# Altium schematic sheet Analog Sensors.SchDoc("Analog Sensors")
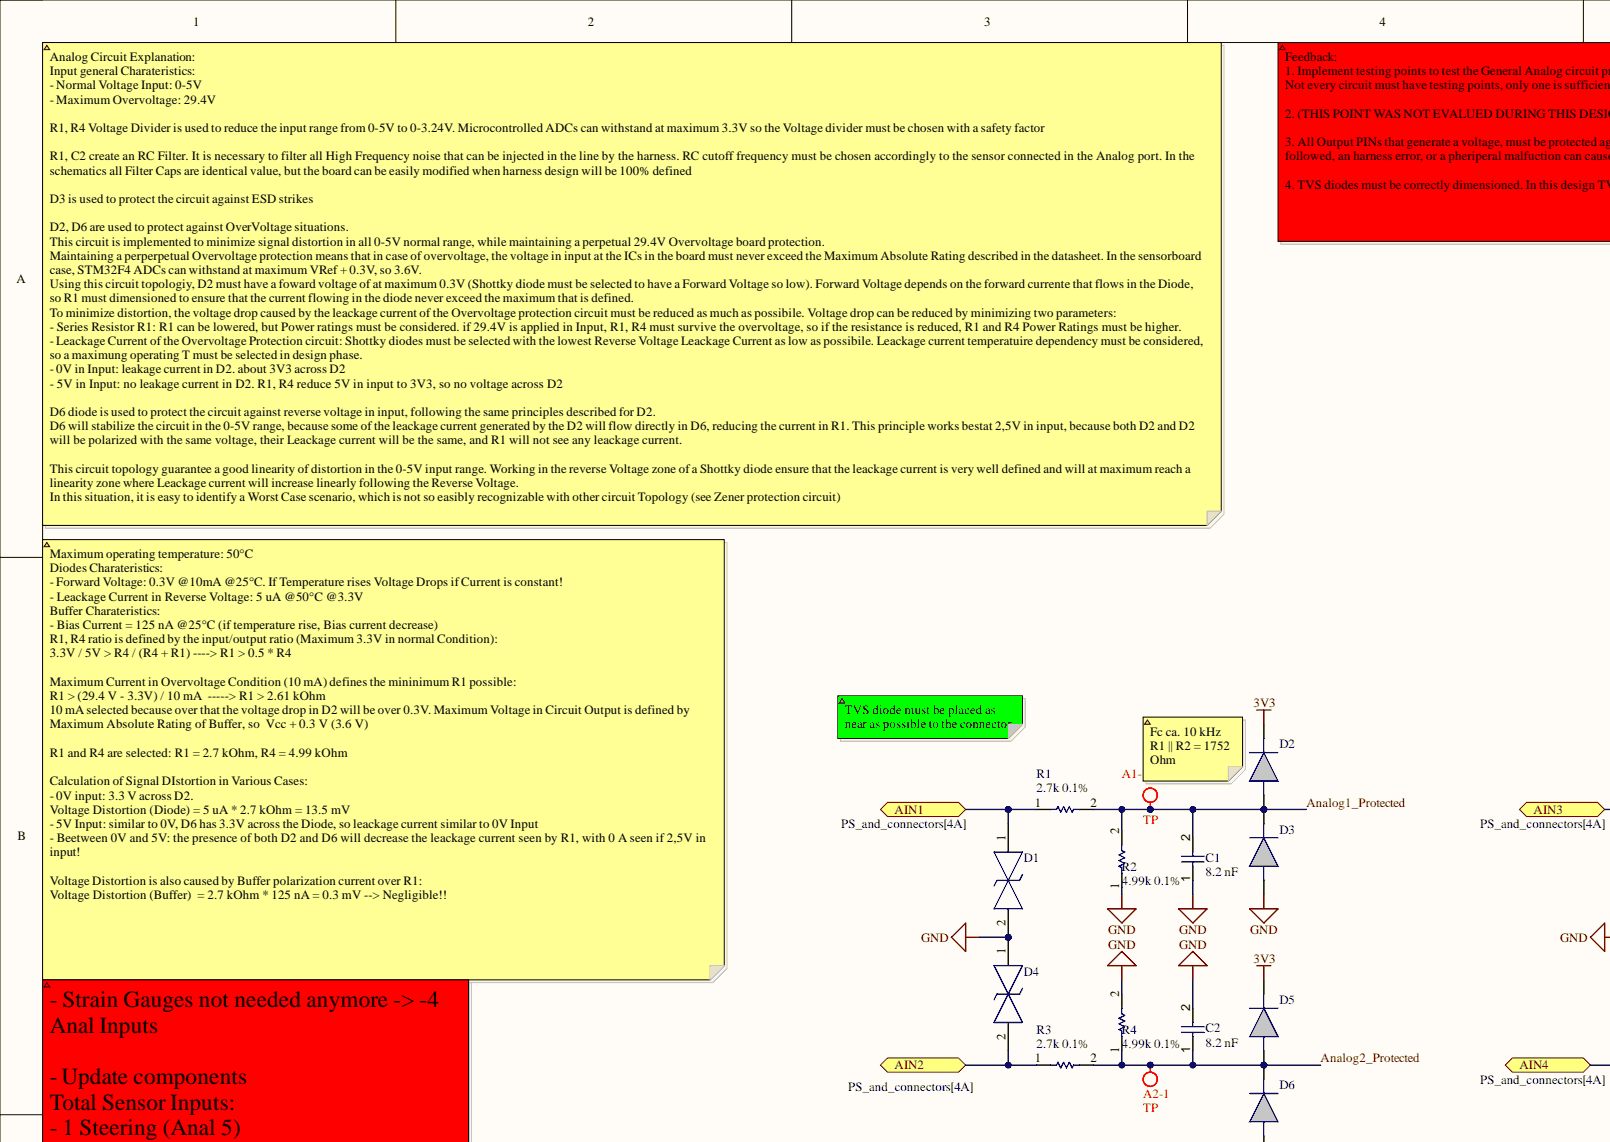
[diagram p0: Analog Sensors.SchDoc, top left]
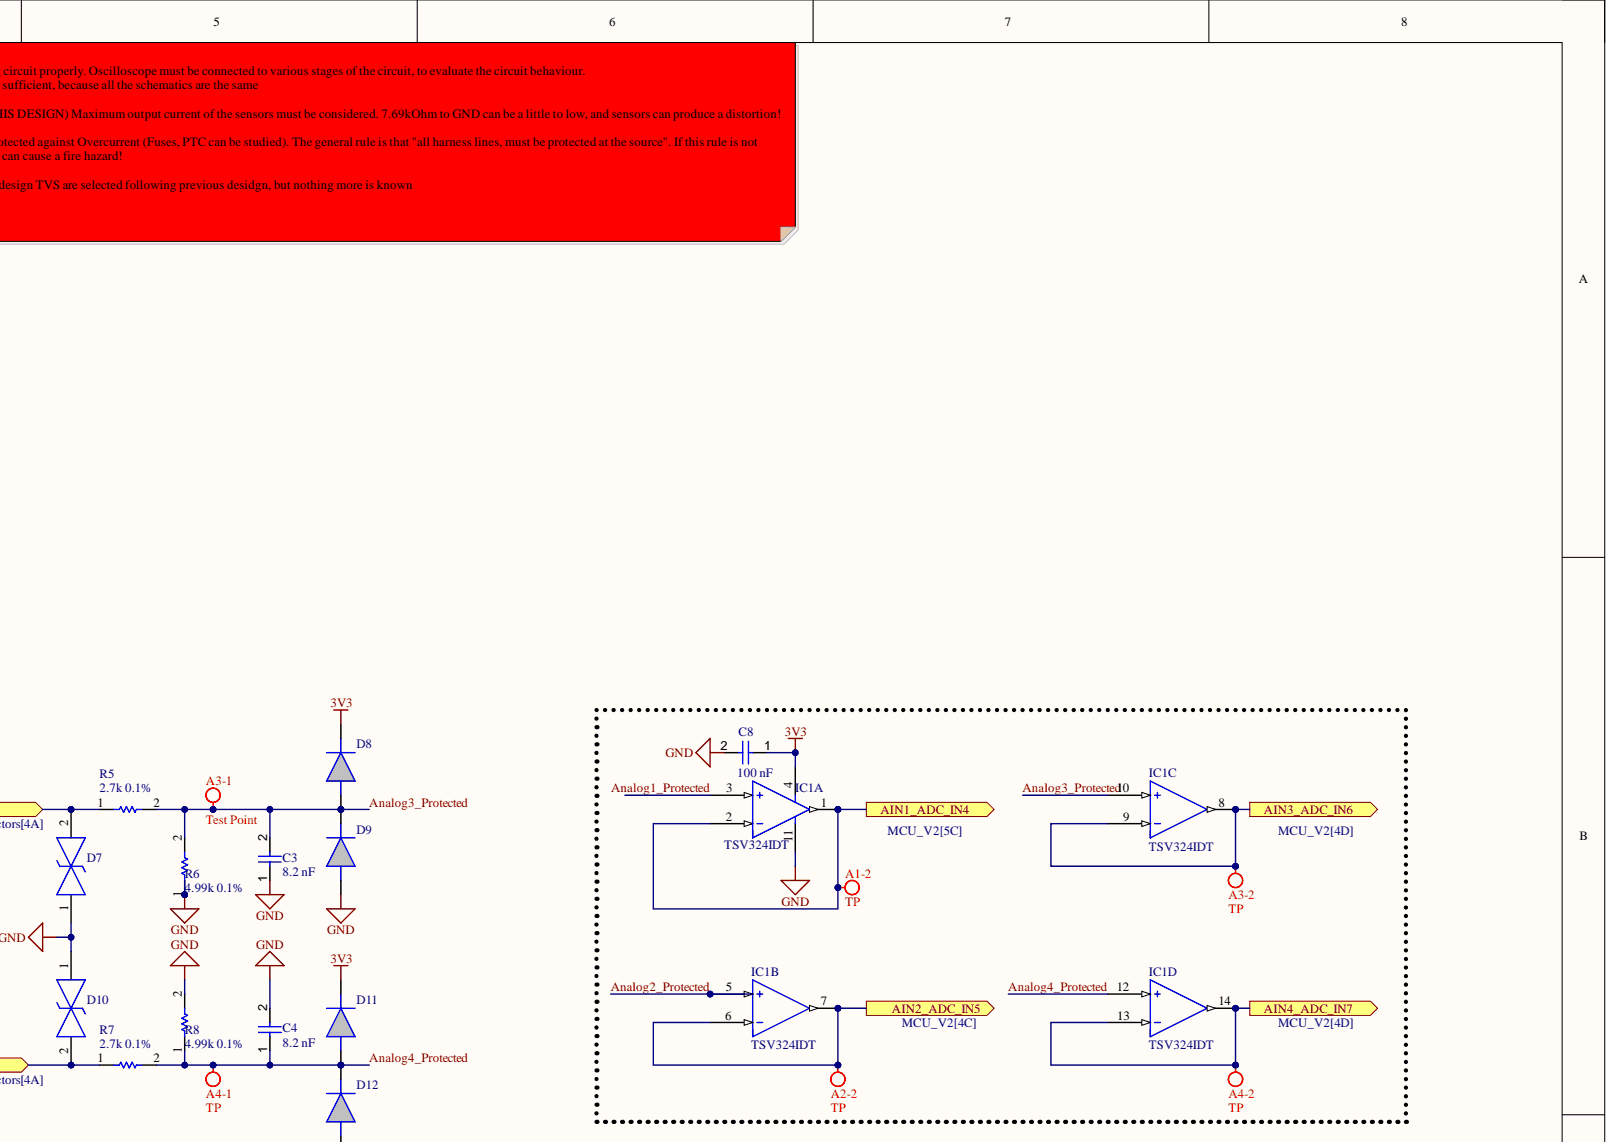
[diagram p1: Analog Sensors.SchDoc, top right]
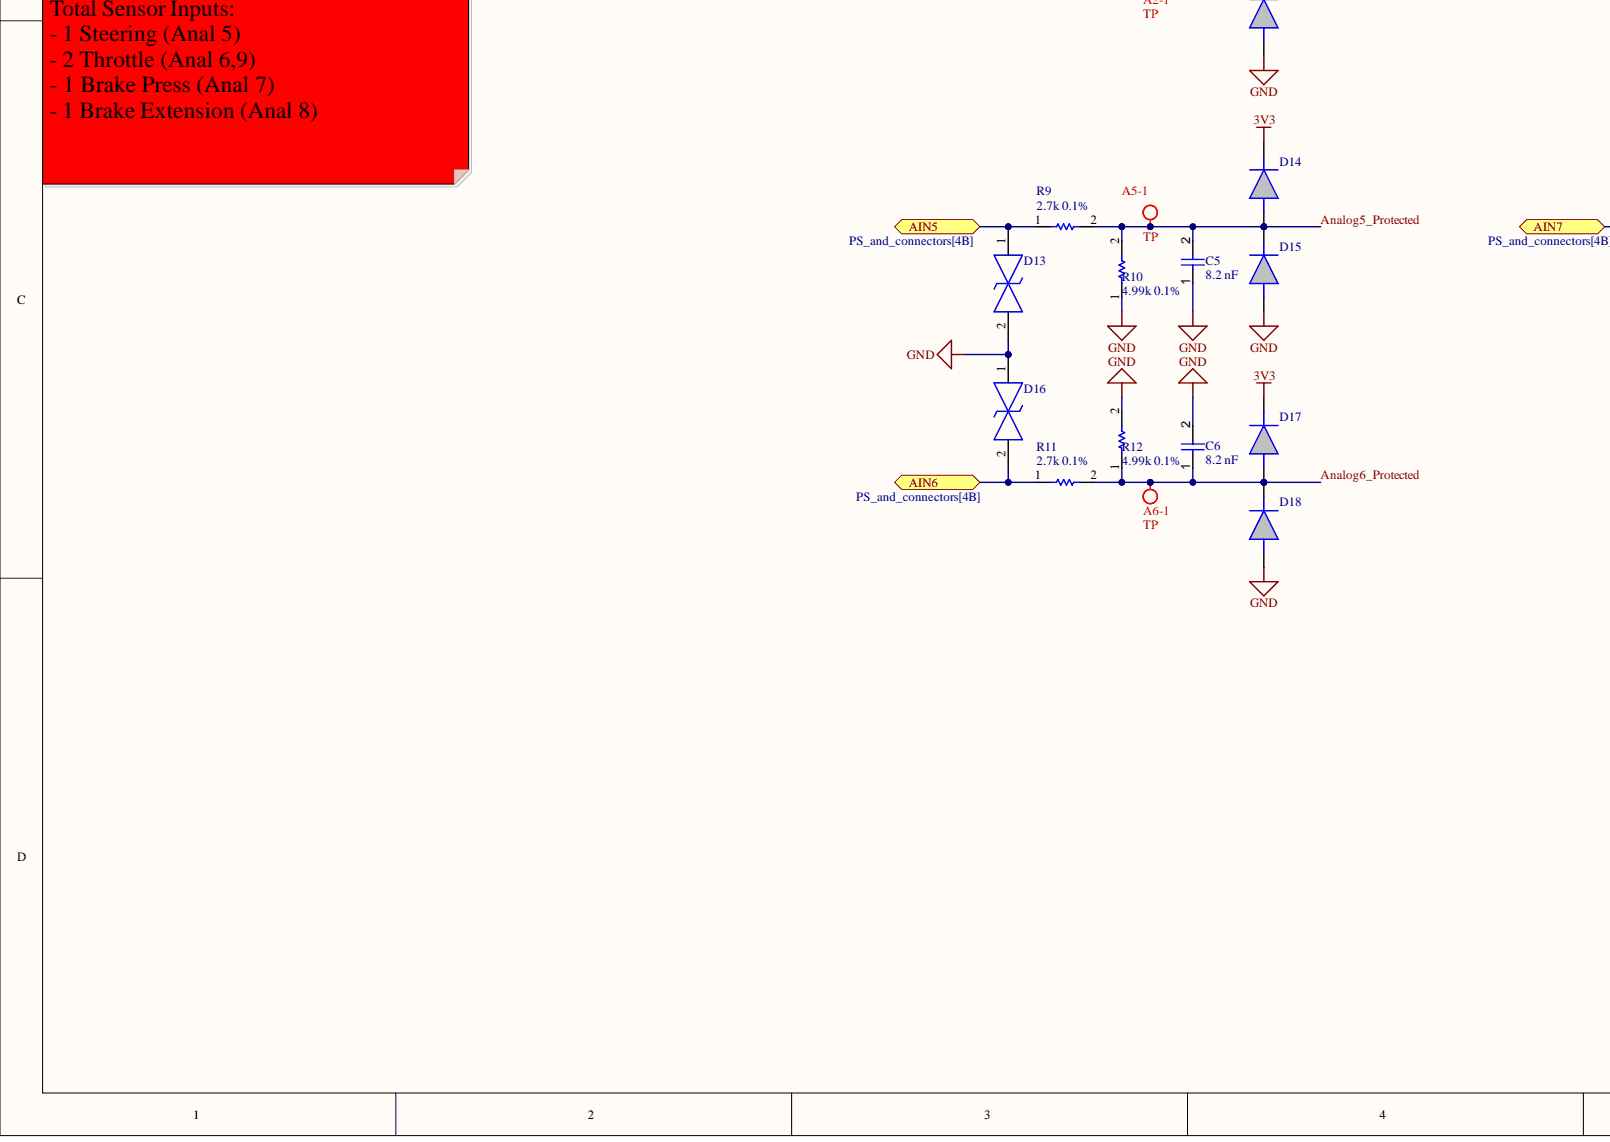
[diagram p2: Analog Sensors.SchDoc, bottom left]
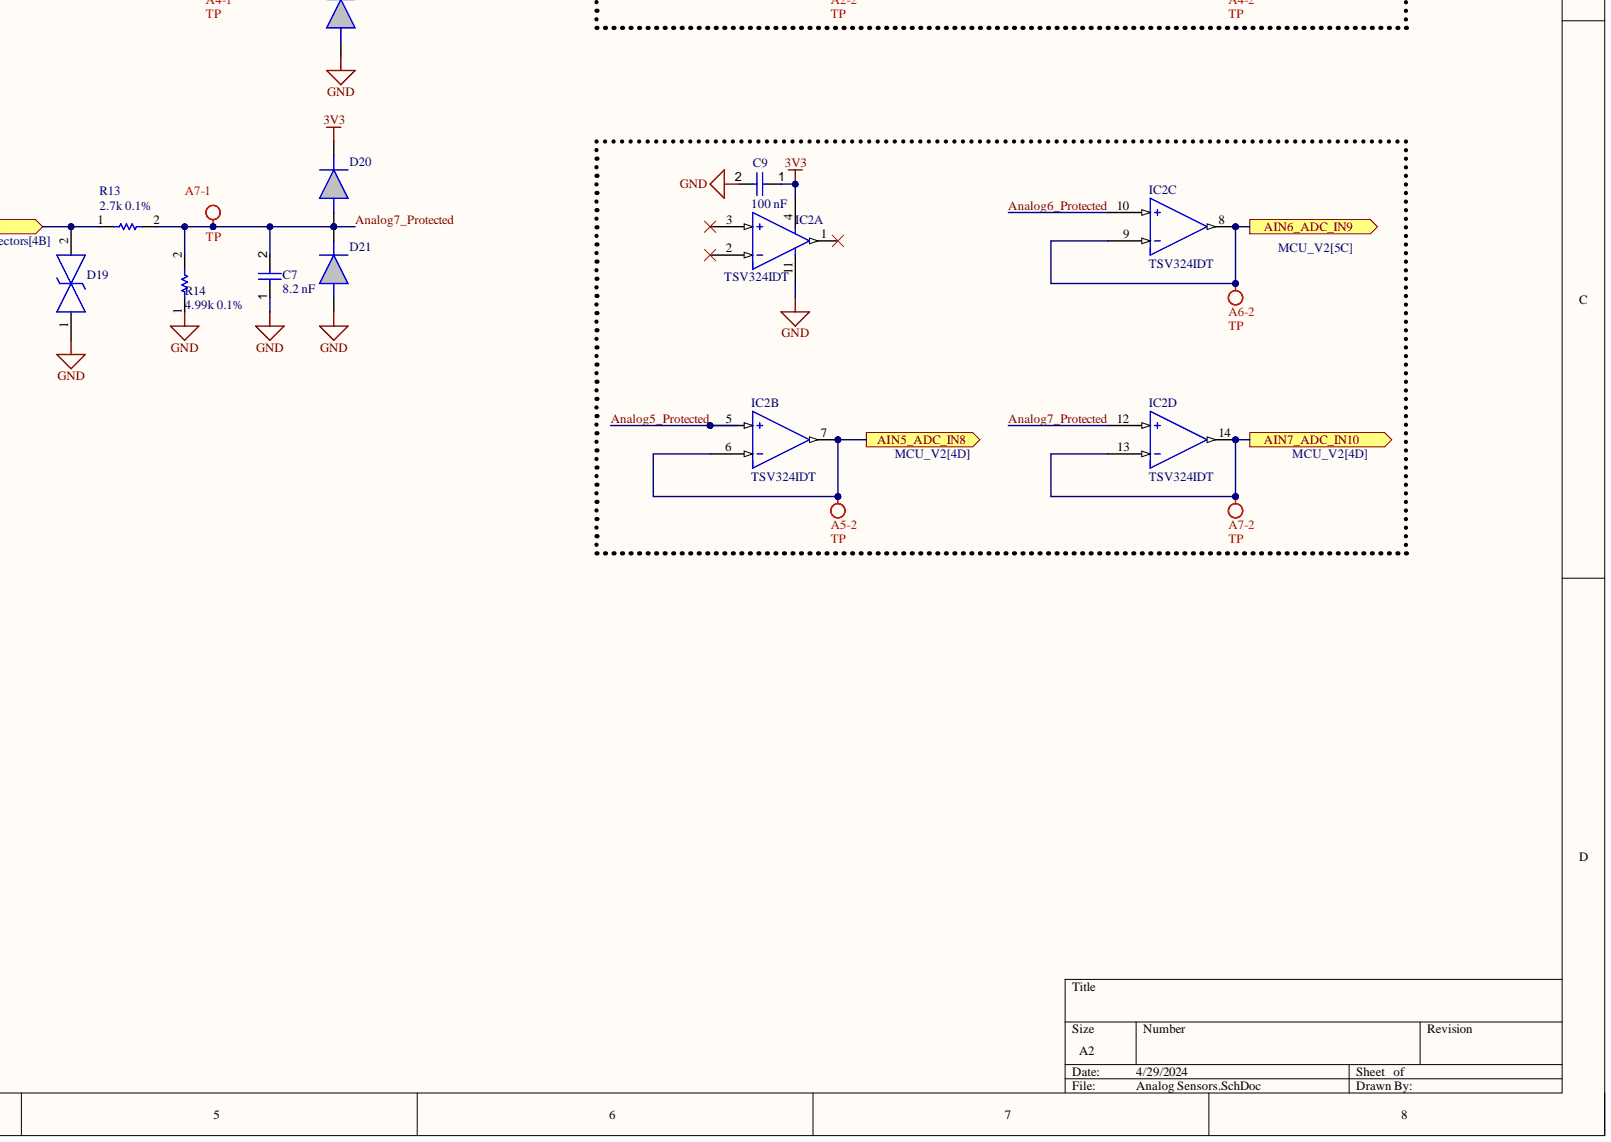
[diagram p3: Analog Sensors.SchDoc, bottom right]

## Parts
A1-1  TP
A1-2  TP
A2-1  TP
A2-2  TP
A3-1  Test Point
A3-2  TP
A4-1  TP
A4-2  TP
A5-1  TP
A5-2  TP
A6-1  TP
A6-2  TP
A7-1  TP
A7-2  TP
C1  8.2 nF
C2  8.2 nF
C3  8.2 nF
C4  8.2 nF
C5  8.2 nF
C6  8.2 nF
C7  8.2 nF
C8  100 nF
C9  100 nF
D1  SMAJ30CA-TR  (value not drawn)
D2  CD0603-B0340R  (value not drawn)
D3  CD0603-B0340R  (value not drawn)
D4  SMAJ30CA-TR  (value not drawn)
D5  CD0603-B0340R  (value not drawn)
D6  CD0603-B0340R  (value not drawn)
D7  SMAJ30CA-TR  (value not drawn)
D8  CD0603-B0340R  (value not drawn)
D9  CD0603-B0340R  (value not drawn)
D10  SMAJ30CA-TR  (value not drawn)
D11  CD0603-B0340R  (value not drawn)
D12  CD0603-B0340R  (value not drawn)
D13  SMAJ30CA-TR  (value not drawn)
D14  CD0603-B0340R  (value not drawn)
D15  CD0603-B0340R  (value not drawn)
D16  SMAJ30CA-TR  (value not drawn)
D17  CD0603-B0340R  (value not drawn)
D18  CD0603-B0340R  (value not drawn)
D19  SMAJ30CA-TR  (value not drawn)
D20  CD0603-B0340R  (value not drawn)
D21  CD0603-B0340R  (value not drawn)
IC1  TSV324IDT  (parts A,B,C,D)
IC2  TSV324IDT  (parts A,B,C,D)
R1  2.7k 0.1%
R2  4.99k 0.1%
R3  2.7k 0.1%
R4  4.99k 0.1%
R5  2.7k 0.1%
R6  4.99k 0.1%
R7  2.7k 0.1%
R8  4.99k 0.1%
R9  2.7k 0.1%
R10  4.99k 0.1%
R11  2.7k 0.1%
R12  4.99k 0.1%
R13  2.7k 0.1%
R14  4.99k 0.1%

## Ports
AIN1, AIN1_ADC_IN4, AIN2, AIN2_ADC_IN5, AIN3, AIN3_ADC_IN6, AIN4, AIN4_ADC_IN7, AIN5, AIN5_ADC_IN8, AIN6, AIN6_ADC_IN9, AIN7, AIN7_ADC_IN10

## Nets
3V3: C8.1 C9.1 D2.1 D5.1 D8.1 D11.1 D14.1 D17.1 D20.1 IC1.4 IC2.4
GND: C1.1 C2.2 C3.1 C4.2 C5.1 C6.2 C7.1 C8.2 C9.2 D1.2 D3.2 D4.1 D6.2 D7.1 D9.2 D10.1 D12.2 D13.2 D15.2 D16.1 D18.2 D19.1 D21.2 IC1.11 IC2.11 R2.1 R4.2 R6.1 R8.2 R10.1 R12.2 R14.1
AIN1: D1.1 R1.1 ; port AIN1
AIN1_ADC_IN4: A1-2.1 IC1.1 IC1.2 ; port AIN1_ADC_IN4
AIN2: D4.2 R3.1 ; port AIN2
AIN2_ADC_IN5: A2-2.1 IC1.6 IC1.7 ; port AIN2_ADC_IN5
AIN3: D7.2 R5.1 ; port AIN3
AIN3_ADC_IN6: A3-2.1 IC1.8 IC1.9 ; port AIN3_ADC_IN6
AIN4: D10.2 R7.1 ; port AIN4
AIN4_ADC_IN7: A4-2.1 IC1.13 IC1.14 ; port AIN4_ADC_IN7
AIN5: D13.1 R9.1 ; port AIN5
AIN5_ADC_IN8: A5-2.1 IC2.6 IC2.7 ; port AIN5_ADC_IN8
AIN6: D16.2 R11.1 ; port AIN6
AIN6_ADC_IN9: A6-2.1 IC2.8 IC2.9 ; port AIN6_ADC_IN9
AIN7: D19.2 R13.1 ; port AIN7
AIN7_ADC_IN10: A7-2.1 IC2.13 IC2.14 ; port AIN7_ADC_IN10
Analog1_Protected: A1-1.1 C1.2 D2.2 D3.1 IC1.3 R1.2 R2.2
Analog2_Protected: A2-1.1 C2.1 D5.2 D6.1 IC1.5 R3.2 R4.1
Analog3_Protected: A3-1.1 C3.2 D8.2 D9.1 IC1.10 R5.2 R6.2
Analog4_Protected: A4-1.1 C4.1 D11.2 D12.1 IC1.12 R7.2 R8.1
Analog5_Protected: A5-1.1 C5.2 D14.2 D15.1 IC2.5 R9.2 R10.2
Analog6_Protected: A6-1.1 C6.1 D17.2 D18.1 IC2.10 R11.2 R12.1
Analog7_Protected: A7-1.1 C7.2 D20.2 D21.1 IC2.12 R13.2 R14.2

Not connected: IC2 1 2 3

## Library data of the parts
A1-1 A1-2 A2-1 A2-2 A3-2 A4-1 A4-2 A5-1 A5-2 A6-1 A6-2 A7-2 = CMP-002-00071-2: Test Point; Component Kind: Standard; Library Name: EMEA- Squadra Corse PoliTO-  Politecnico di Torino
A3-1 = CMP-002-00071-2: Component Kind: Standard; Description: Test Point; Library Name: EMEA- Squadra Corse PoliTO-  Politecnico di Torino
A7-1 = CMP-002-00071-2: A7-1; Component Kind: Standard; Library Name: EMEA- Squadra Corse PoliTO-  Politecnico di Torino
C1 = CMP-00009-1: SMAJ30CA-TR; Component Kind: Standard; Library Name: EMEA- Squadra Corse PoliTO-  Politecnico di Torino
C2-C7 = CMP-00009-1: VJ0805Y822KXBPW1BC; Component Kind: Standard; Library Name: EMEA- Squadra Corse PoliTO-  Politecnico di Torino
C8 C9 = CMP-00009-1: VJ0805Y104MXATW1BC; Component Kind: Standard; Library Name: EMEA- Squadra Corse PoliTO-  Politecnico di Torino
D1 D4 D7 D10 D13 D16 D19 = CMP-004-000005-1: TVS Diode Bi-Directional SMAJ30CA-TR 64.3V; Manufacturer_Name: STMicroelectronics; Arrow Part Number: SMAJ30CA-TR; Arrow Price/Stock: https://www.arrow.com/en/products/smaj30ca-tr/stmicroelectronics?region=nac; Component Kind: Standard; Datasheet Link: https://www.st.com/resource/en/datasheet/smaj10ca.pdf; Design Item ID: SMAJ30CA-TR; Height: 2.65mm; Manufacturer_Part_Number: SMAJ30CA-TR; Mouser Part Number: 511-SMAJ30CA-TR; Mouser Price/Stock: https://www.mouser.co.uk/ProductDetail/STMicroelectronics/SMAJ30CA-TR?qs=0TJjK1waviDY0EkLaKH12g%3D%3D; Source: SamacSys.SchLib; Library Name: EMEA- Squadra Corse PoliTO-  Politecnico di Torino
D2 D3 D5 D6 D8 D9 D11 D12 D14 D15 D17 D18 D20 D21 = CMP-110-000000-5: Bourns 40V 300mA, Diode, 2-Pin 0603 CD0603-B0340R; Geometry.Height: 0.8mm; Component Kind: Standard; Datasheet Link: https://www.bourns.com/docs/product-datasheets/cd0603-b.pdf; Design Item ID: CD0603-B0340R; Manufacturer_Name: Bourns; Manufacturer_Part_Number: CD0603-B0340R; Mouser Part Number: 652-CD0603-B0340R; Mouser Price/Stock: https://www.mouser.co.uk/ProductDetail/Bourns/CD0603-B0340R?qs=xhbEVWpZdWee9K23%252B3DSjQ%3D%3D; Source: CD0603-B0340R.SchLib; Library Name: EMEA- Squadra Corse PoliTO-  Politecnico di Torino
IC1 IC2 = CMP-007-000004-4: Manufacturer_Name: STMicroelectronics; Arrow Part Number: TSV324IDT; Arrow Price/Stock: https://www.arrow.com/en/products/tsv324idt/stmicroelectronics; Component Kind: Standard; Datasheet Link: http://www.st.com/st-web-ui/static/active/en/resource/technical/document/datasheet/CD00063292.pdf; Description: TSV324IDT; Design Item ID: TSV324IDT; Height: 1.75mm; Manufacturer_Part_Number: TSV324IDT; Mouser Part Number: 511-TSV324IDT; Mouser Price/Stock: https://www.mouser.com/Search/Refine.aspx?Keyword=511-TSV324IDT; Source: SamacSys.SchLib; Library Name: EMEA- Squadra Corse PoliTO-  Politecnico di Torino
R1 R3 R5 R7 R9 R11 R13 = CMP-009-00179-5: RT0603BRE072K7L; Tech: SMD; Component Kind: Standard; ComponentLink1Description: Website; Package: 0603; Library Name: EMEA- Squadra Corse PoliTO-  Politecnico di Torino
R2 R4 R6 R8 R10 R12 R14 = CMP-009-00179-5: RT0603BRD074K99L; Tech: SMD; Component Kind: Standard; ComponentLink1Description: Website; Package: 0603; Library Name: EMEA- Squadra Corse PoliTO-  Politecnico di Torino
